# Altium schematic sheet DAC.SchDoc(DAC)
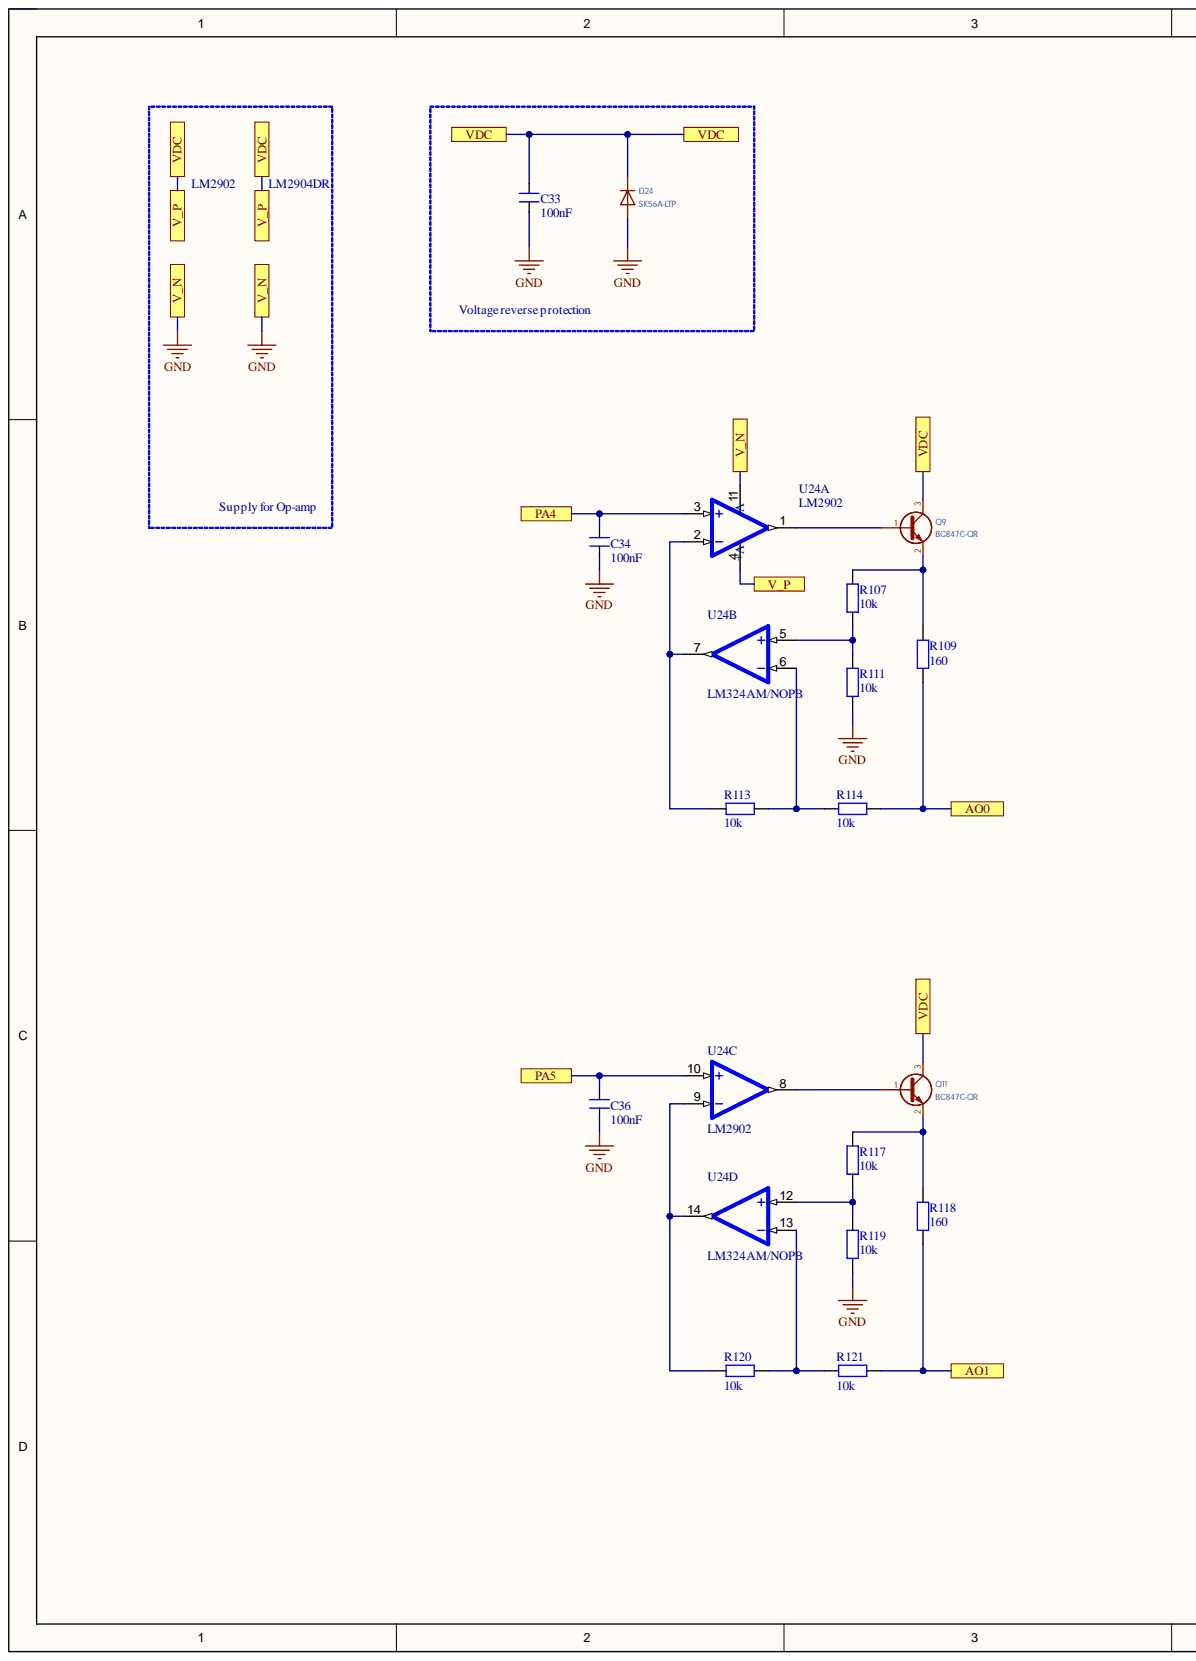
[diagram p0: DAC.SchDoc, left]
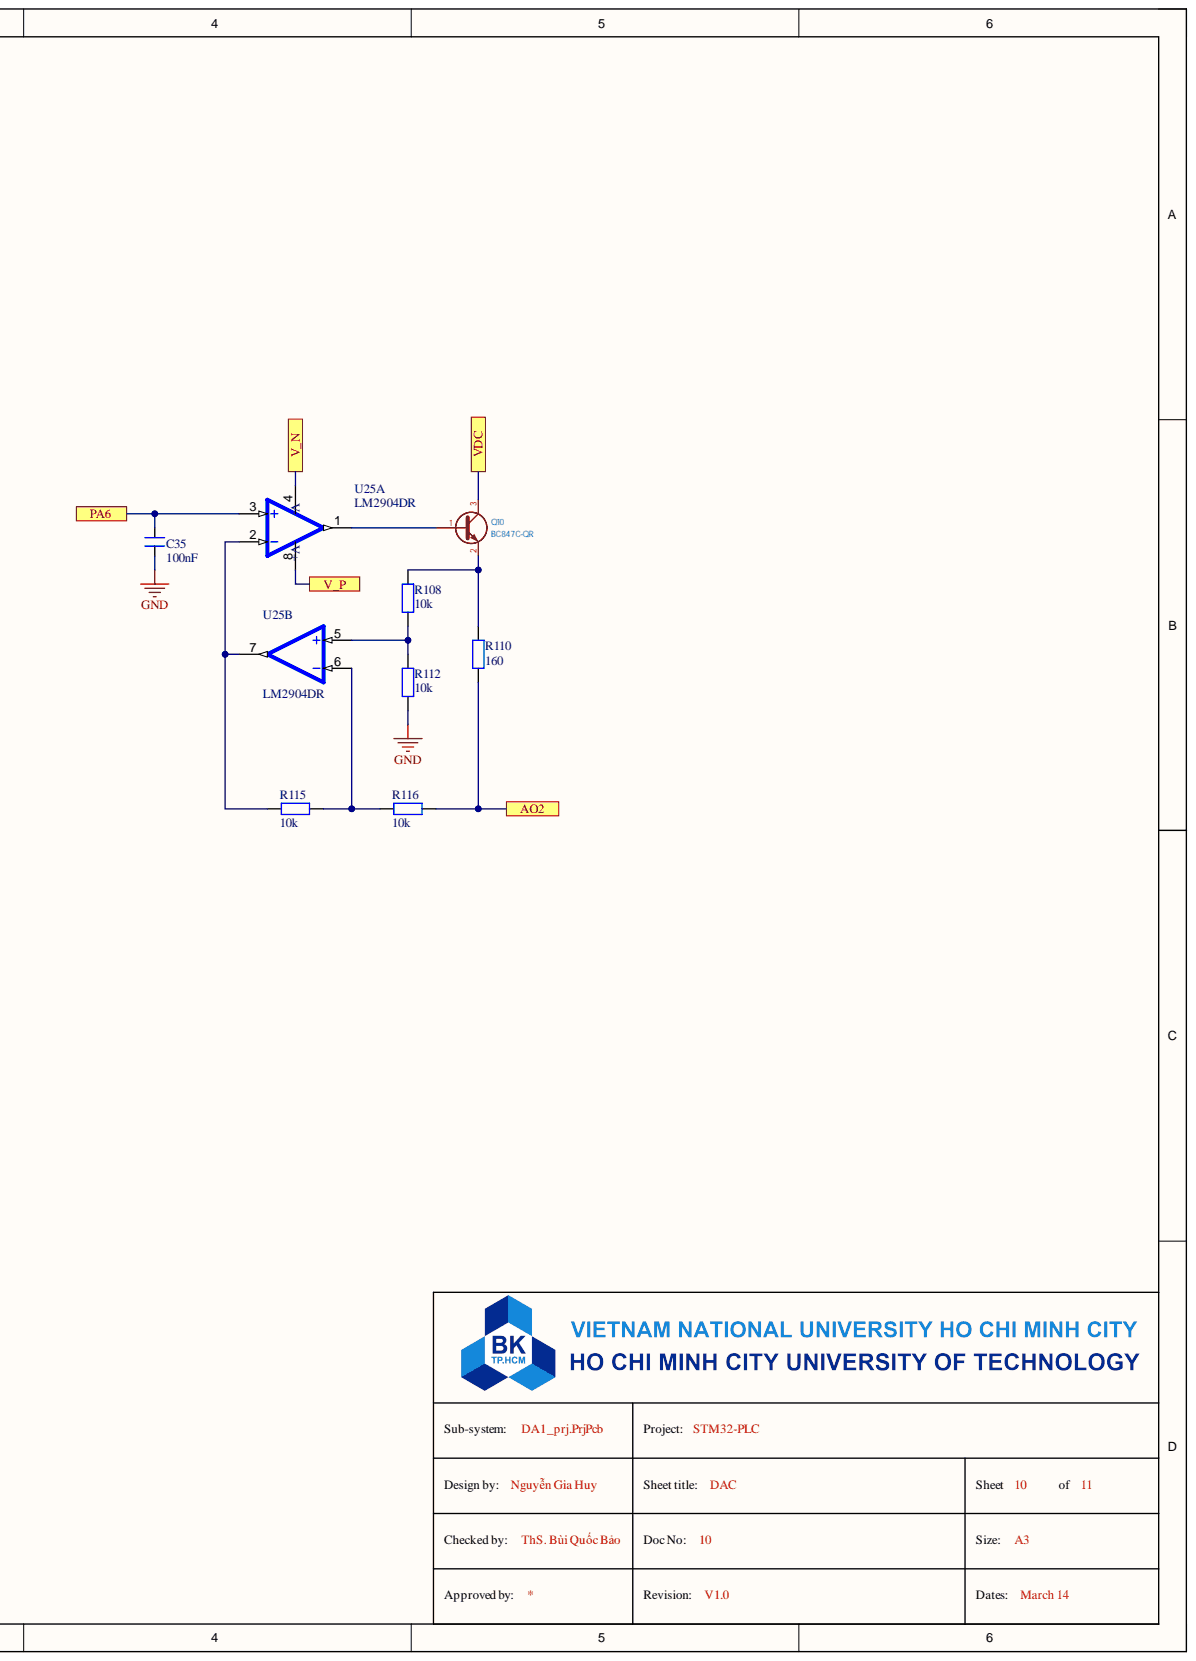
[diagram p1: DAC.SchDoc, right]

## Parts
C33  100nF  [FP-C0603C-CF-IPC_A]
C34  100nF  [FP-C0603C-CF-IPC_A]
C35  100nF  [FP-C0603C-CF-IPC_A]
C36  100nF  [FP-C0603C-CF-IPC_A]
D24  SK56A-LTP  [Diode_SMD:D_SMA]
Q9  BC847C-QR  [Package_TO_SOT_SMD:SOT-23]
Q10  BC847C-QR  [Package_TO_SOT_SMD:SOT-23]
Q11  BC847C-QR  [Package_TO_SOT_SMD:SOT-23]
R107  10k  [tro smd 0603]
R108  10k  [tro smd 0603]
R109  160  [tro smd 0603]
R110  160  [tro smd 0603]
R111  10k  [tro smd 0603]
R112  10k  [tro smd 0603]
R113  10k  [tro smd 0603]
R114  10k  [tro smd 0603]
R115  10k  [tro smd 0603]
R116  10k  [tro smd 0603]
R117  10k  [tro smd 0603]
R118  160  [tro smd 0603]
R119  10k  [tro smd 0603]
R120  10k  [tro smd 0603]
R121  10k  [tro smd 0603]
U24  LM2902  [SOIC127P600X175-14N]  (parts A,B,C,D)
U25  LM2904DR  [SOIC127P599X175-8N]  (parts A,B)

## Ports
AO0, AO1, AO2, PA4, PA5, PA6, V_N, V_P, VDC

## Nets
AO0: R109.1 R114.1 ; port AO0
AO1: R118.1 R121.1 ; port AO1
AO2: R110.1 R116.1 ; port AO2
GND: C33.2 C34.2 C35.2 C36.2 D24.2 R111.1 R112.1 R119.1 U24.11 U25.4 ; port V_N
PA4: C34.1 U24.3(V-) ; port PA4
PA5: C36.1 U24.10 ; port PA5
PA6: C35.1 U25.3(V-) ; port PA6
VDC: C33.1 D24.1 Q9.3 Q10.3 Q11.3 U24.4 U25.8 ; port VDC, port V_P
NetQ9_1: Q9.1 U24.1
NetQ9_2: Q9.2 R107.2 R109.2
NetQ10_1: Q10.1 U25.1
NetQ10_2: Q10.2 R108.2 R110.2
NetQ11_1: Q11.1 U24.8
NetQ11_2: Q11.2 R117.2 R118.2
NetR107_1: R107.1 R111.2 U24.5
NetR108_1: R108.1 R112.2 U25.5
NetR113_1: R113.1 R114.2 U24.6
NetR113_2: R113.2 U24.2 U24.7
NetR115_1: R115.1 R116.2 U25.6
NetR115_2: R115.2 U25.2 U25.7
NetR117_1: R117.1 R119.2 U24.12
NetR120_1: R120.1 R121.2 U24.13
NetR120_2: R120.2 U24.9 U24.14

## Library data of the parts
C33-C36 = CN-SEMI-DIP: Capacitor (Semiconductor SIM Model); Value: 104; Component Kind: Standard; LatestRevisionDate: 17-Jul-2002; LatestRevisionNote: Re-released for DXP Platform.; Library Name: LIBRARY.IntLib; PackageReference: C1206; Publisher: Altium Limited
D24 = D: Component Kind: Standard; Library Name: Device; Value: SK56A-LTP
Q9-Q11 = BC847: Component Kind: Standard; LCSC: C2145; Library Name: Transistor_BJT; Value: BC847C-QR
R107-R121 = Tro 0603 1% 10k: RES; Chung_Loai: Dán; Don_Vi: Con; Ma_Hang: MH006333; So_Chan: 2; Ten_Linh_Kien: Trở 0603 1% 10k; Component Kind: Standard; Library Name: AUSB.SchLib
U24 = LM324AM/NOPB: Low power general-purpose quad operational amplifier 14-SOIC 0 to 70; MF: Texas Instruments; Check_prices: https://www.snapeda.com/parts/LM324AM/Texas+Instruments/view-part/?ref=eda; Component Kind: Standard; Library Name: LM324AM_NOPB.IntLib; MP: LM324AM; Package: SOIC-14 Texas Instruments; SnapEDA_Link: https://www.snapeda.com/parts/LM324AM/Texas+Instruments/view-part/?ref=snap
U25 = LM2904DR: Dual, 26-V, 700-kHz operational amplifier; MF: Texas Instruments; Check_prices: https://www.snapeda.com/parts/LM2904DR/Texas+Instruments/view-part/?ref=eda; Component Kind: Standard; Library Name: LM2904DR.IntLib; MP: LM2904DR; Package: SOIC-8 Texas Instruments; SnapEDA_Link: https://www.snapeda.com/parts/LM2904DR/Texas+Instruments/view-part/?ref=snap
